# Altium schematic sheet traintrackr_schematic.SchDoc
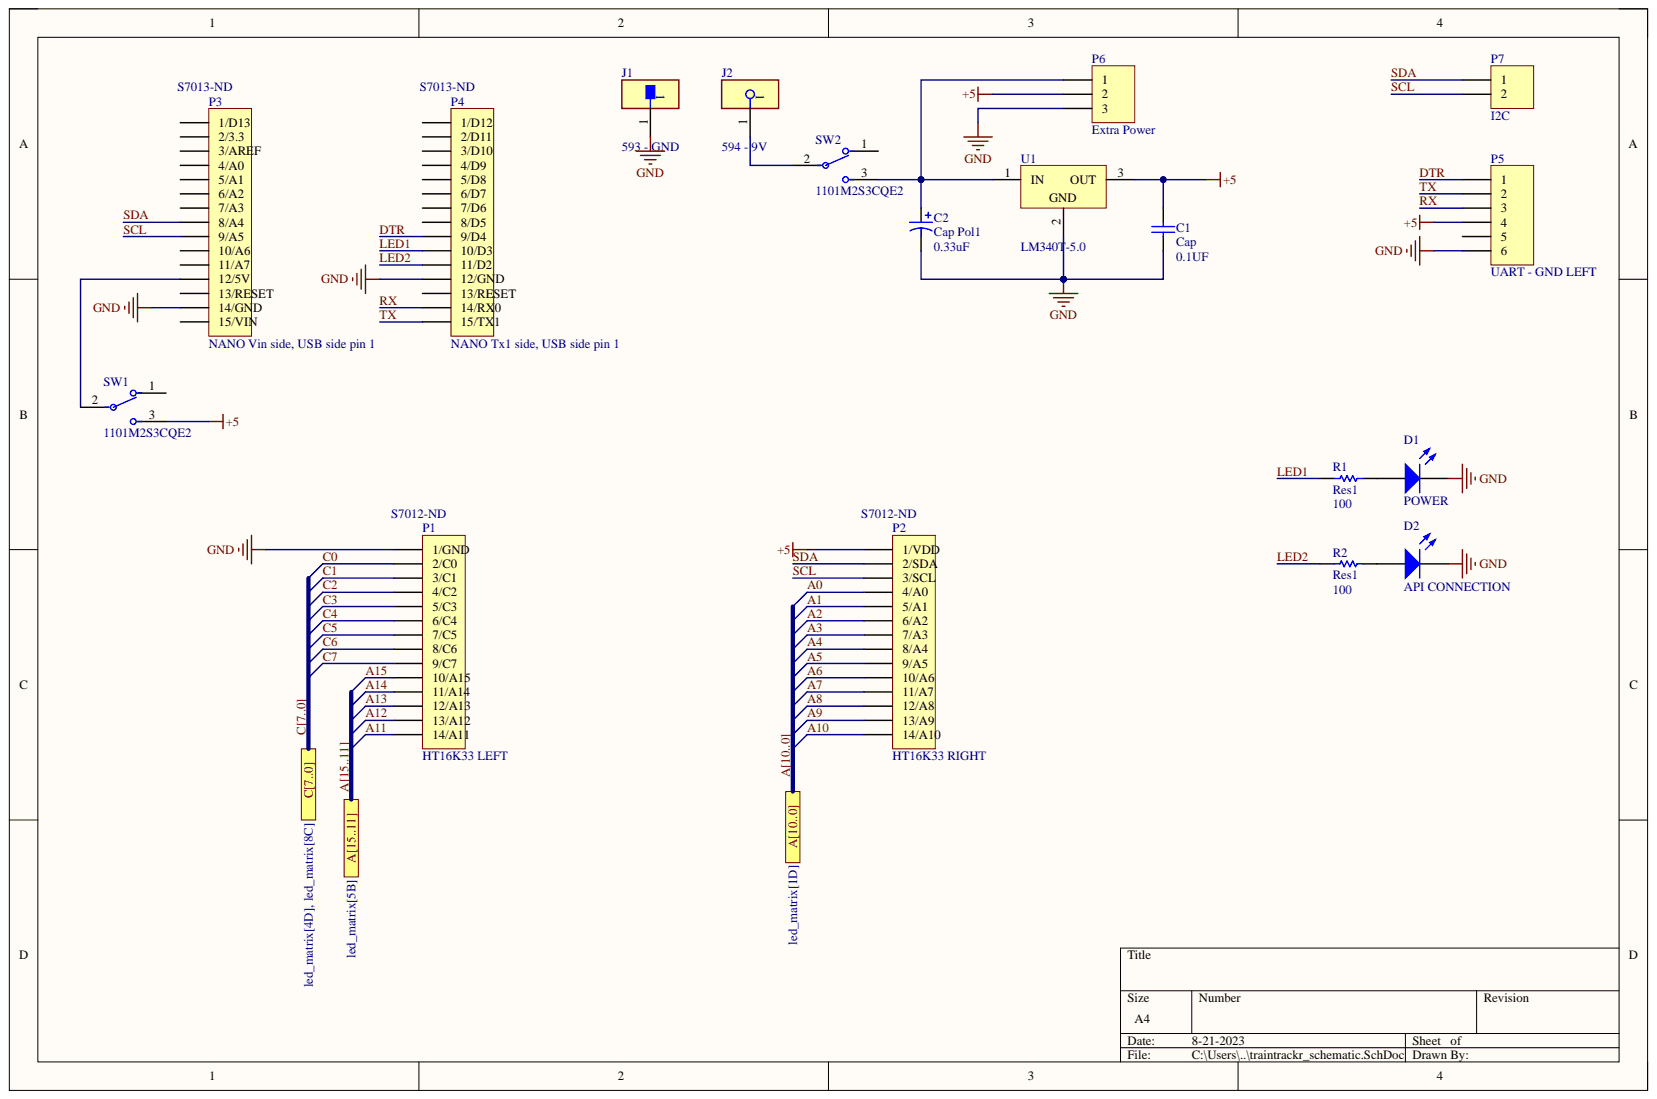
[diagram p0: traintrackr_schematic.SchDoc]

## Parts
C1  Cap  value 0.1UF  [RAD-0.1]
C2  Cap Pol1  value 0.33uF  [Capacitor 330nF]
D1  POWER  [LED_5MM]
D2  API CONNECTION  [LED_5MM]
J1  593 - GND  [FP-593-MFG]
J2  594 - 9V  [FP-594-MFG]
P1  HT16K33 LEFT  Supplier Part Number 1: S7012-ND  [HDR1X14]
P2  HT16K33 RIGHT  Supplier Part Number 1: S7012-ND  [HDR1X14]
P3  NANO Vin side, USB side pin 1  Supplier Part Number 1: S7013-ND  [HDR1X15]
P4  NANO Tx1 side, USB side pin 1  Supplier Part Number 1: S7013-ND  [HDR1X15]
P5  UART - GND LEFT  [HDR1X6H]
P6  Extra Power  [HDR1X3]
P7  I2C  [RAD-0.1]
R1  Res1  value 100  [AXIAL-0.3]
R2  Res1  value 100  [AXIAL-0.3]
SW1  1101M2S3CQE2  [FP-1101M2S3CQE2-MFG]
SW2  1101M2S3CQE2  [FP-1101M2S3CQE2-MFG]
U1  LM340T-5.0  [NDE0003A]

## Ports
A0, A1, A2, A3, A4, A5, A6, A7, A8, A9, A10, A11, A12, A13, A14, A15, A[10..0], A[15..11], C0, C1, C2, C3, C4, C5, C6, C7, C[7..0]

## Nets
+5: C1.2 P2.1 P5.4 P6.2 SW1.3 U1.3
GND: C1.1 C2.2 D1.2 D2.2 J1.1 P1.1 P3.14 P4.12 P5.6 P6.3 U1.2
A0: P2.4(4/A0) ; port A[10..0]  [also labelled A]
A1: P2.5(5/A1) ; port A[10..0]  [also labelled A]
A2: P2.6(6/A2) ; port A[10..0]  [also labelled A]
A3: P2.7(7/A3) ; port A[10..0]  [also labelled A]
A4: P2.8(8/A4) ; port A[10..0]  [also labelled A]
A5: P2.9(9/A5) ; port A[10..0]  [also labelled A]
A6: P2.10(10/A6) ; port A[10..0]  [also labelled A]
A7: P2.11(11/A7) ; port A[10..0]  [also labelled A]
A8: P2.12(12/A8) ; port A[10..0]  [also labelled A]
A9: P2.13(13/A9) ; port A[10..0]  [also labelled A]
A10: P2.14 ; port A[10..0]  [also labelled A]
A11: P1.14 ; port A[15..11]  [also labelled A]
A12: P1.13 ; port A[15..11]  [also labelled A]
A13: P1.12 ; port A[15..11]  [also labelled A]
A14: P1.11 ; port A[15..11]  [also labelled A]
A15: P1.10 ; port A[15..11]  [also labelled A]
C0: P1.2(2/C0) ; port C[7..0]  [also labelled C]
C1: P1.3(3/C1) ; port C[7..0]  [also labelled C]
C2: P1.4(4/C2) ; port C[7..0]  [also labelled C]
C3: P1.5(5/C3) ; port C[7..0]  [also labelled C]
C4: P1.6(6/C4) ; port C[7..0]  [also labelled C]
C5: P1.7(7/C5) ; port C[7..0]  [also labelled C]
C6: P1.8(8/C6) ; port C[7..0]  [also labelled C]
C7: P1.9(9/C7) ; port C[7..0]  [also labelled C]
DTR: P4.9(9/D4) P5.1
LED1: P4.10(10/D3) R1.1
LED2: P4.11(11/D2) R2.1
RX: P4.14 P5.3
SCL: P2.3(3/SCL) P3.9(9/A5) P7.2
SDA: P2.2 P3.8(8/A4) P7.1
TX: P4.15 P5.2
NetC2_1: C2.1 P6.1 SW2.3 U1.1
NetD1_1: D1.1 R1.2
NetD2_1: D2.1 R2.2
NetJ2_1: J2.1 SW2.2
NetP3_12: P3.12(12/5V) SW1.2
A[10..0]: ; port A[10..0]  [also labelled A]
A[15..11]: ; port A[15..11]  [also labelled A]

Not connected: P3 1(1/D13) 2(2/3.3) 3 4(4/A0) 5(5/A1) 6(6/A2) 7(7/A3) 10(10/A6) 11(11/A7) 13 15; P4 1(1/D12) 2(2/D11) 3(3/D10) 4(4/D9) 5(5/D8) 6(6/D7) 7(7/D6) 8(8/D5) 13; P5 5; SW1 1; SW2 1

## Library data of the parts
C1 = CAP CER 0.1UF 50V X7R RADIAL: Capacitor; Manufacturer Part Number: K104M15X7RF5TH5; Voltage Rating: 50V; Component Kind: Standard; Designator: C?; LatestRevisionDate: 17-Jul-2002; LatestRevisionNote: Re-released for DXP Platform.; Library Name: ECE295-Capacitors-TH.IntLib; Published: 8-Jun-2000; Signal Integrity: Cap; Simulation: CAP; Publisher: Altium Limited
C2 = Cap Pol1: Polarized Capacitor (Radial); Component Kind: Standard; LatestRevisionDate: 17-Jul-2002; LatestRevisionNote: Re-released for DXP Platform.; Library Name: Miscellaneous Devices.IntLib; PackageDescription: Polarized Capacitor (Radial); 2 Leads; PackageReference: RB7.6-15; Published: 8-Jun-2000; Signal Integrity: Cap Pol; Simulation: CAP; Publisher: Altium Limited
D1 D2 = LED1: Typical RED GaAs LED; Component Kind: Standard; LatestRevisionDate: 08-Jul-2005; LatestRevisionNote: Mech Layer 1 removed.; Library Name: Miscellaneous Devices.IntLib; PackageDescription: LED; 2 Leads; PackageReference: LED-1; Published: 8-Jun-2000; Simulation: LED1; Publisher: Altium Limited
J1 = CMP-19636-000031-1: BATTERY CONTACT SNAP 9V PC PIN; Body Material: Steel; Body Plating: Tin; Connector Type: Snap in; Gender: Male; Material Thickness: 0.15inch; Number of Batteries: 1; Component Kind: Standard; Contact Material: Steel; Contact Plating: Nickel; Contact Type: Snap-In; Lead Free: Lead Free; Length: 9.65mm; Library Name: Altium Content Vault; Material: Steel; Mount: Through Hole; Number of Cells: 1; Orientation: Right Angle; Package Quantity: 100; Packaging: Bulk; Reach SVHC Compliant: Yes; RoHS Compliant: Yes; Series: -; Termination: PC Pin; Thickness: 0.015inch
J2 = CMP-19636-000029-1: BATTERY CONTACT SNAP 9V PC PIN; Body Material: Steel; Body Plating: Tin; Connector Type: Snap in; Gender: Female; Material Thickness: 0.15inch; Number of Batteries: 1; Component Kind: Standard; Contact Material: Steel; Contact Plating: Nickel; Contact Type: Snap-In; Lead Free: Lead Free; Length: 9.65mm; Library Name: Altium Content Vault; Material: Steel; Mount: Through Hole; Number of Cells: 1; Orientation: Right Angle; Package Quantity: 100; Packaging: Bulk; Reach SVHC Compliant: Yes; RoHS Compliant: Yes; Series: -; Termination: PC Pin; Thickness: 0.015inch
P1 P2 = Header 14: Header, 14-Pin; Component Kind: Standard; LatestRevisionDate: 17-Jul-2002; LatestRevisionNote: Re-released for DXP Platform.; Library Name: Miscellaneous Connectors.IntLib; Signal Integrity: Connector; Supplier 1: Digi-Key; Publisher: Altium Limited
P3 P4 = Header 15: Header, 15-Pin; Component Kind: Standard; LatestRevisionDate: 17-Jul-2002; LatestRevisionNote: Re-released for DXP Platform.; Library Name: Miscellaneous Connectors.IntLib; Signal Integrity: Connector; Supplier 1: Digi-Key; Publisher: Altium Limited
P5 = Header 6H: Header, 6-Pin, Right Angle; Component Kind: Standard; LatestRevisionDate: 17-Jul-2002; LatestRevisionNote: Re-released for DXP Platform.; Library Name: Miscellaneous Connectors.IntLib; Signal Integrity: Connector; Supplier 1: Digi-Key; Supplier Part Number 1: S1111EC-06-ND; Publisher: Altium Limited
P6 = Header 3: Header, 3-Pin; Component Kind: Standard; LatestRevisionDate: 17-Jul-2002; LatestRevisionNote: Re-released for DXP Platform.; Library Name: Miscellaneous Connectors.IntLib; Signal Integrity: Connector; Supplier 1: Digi-Key; Supplier Part Number 1: 2057-PH1-20-UA-ND; Publisher: Altium Limited
P7 = Header 2H: Header, 2-Pin, Right Angle; Component Kind: Standard; LatestRevisionDate: 17-Jul-2002; LatestRevisionNote: Re-released for DXP Platform.; Library Name: Miscellaneous Connectors.IntLib; Signal Integrity: Connector; Supplier 1: Digi-Key; Supplier Part Number 1: 2057-PH1-20-UA-ND; Publisher: Altium Limited
R1 R2 = Res1: Resistor; Component Kind: Standard; LatestRevisionDate: 17-Jul-2002; LatestRevisionNote: Re-released for DXP Platform.; Library Name: Miscellaneous Devices.IntLib; PackageDescription: Axial Device, Thru-Hole; 2 Leads; 0.3 in Pin Spacing; PackageReference: AXIAL-0.3; Published: 8-Jun-2000; Signal Integrity: Res; Simulation: RESISTOR; Publisher: Altium Limited
SW1 SW2 = CMP-10038-000019-1: SWITCH SLIDE SPDT 6A 120V; Actuator Color: Black; Actuator Length: 5.08mm; Actuator Material: Nylon; Actuator Type: Standard; Approvals: UL; Body Material: Stainless Steel; Contact Resistance: 10mR; Electrical Life: 40000Cycles; Insulation Resistance: 1GR; Max Voltage Rating (AC): 250V; Max Voltage Rating (DC): 28V; Number of Poles: 1; Number of Positions: 3; Switch Function: On-On; Switch Travel: 2.16mm; Component Kind: Standard; Contact Current Rating: 6A; Contact Material: Copper; Contact Plating: Silver; Current Rating: 6A; Depth: 6.6mm; Flammability Rating: UL94 V-0; Housing Material: Stainless Steel; Lead Free: Lead Free; Length: 12.7mm; Library Name: Altium Content Vault; Max Current Rating: 6A; Max Operating Temperature: 65°C; Min Operating Temperature: -30°C; Mount: Through Hole; Orientation: Vertical; Package Quantity: 1; Packaging: Bulk; REACH SVHC: No SVHC; Series: 1000; Termination: PC Pins; Throw Configuration: SPDT; Voltage Rating (AC): 120V; Voltage Rating (DC): 28V
U1 = CMP-0062-02468-3: Series 3-Terminal Positive Regulators, 3-pin TO-220; Manufacturer: Texas Instruments; CompType: LDO IC; PackageTopMarking: NSUZXYTTE#*LM340T5*7805 P+; PowerWise: N; Component Kind: Standard; ComponentLink1Description: Datasheet URL; ComponentLink1URL: http://www.ti.com/general/docs/lit/getliterature.tsp?genericPartNumber=LM340-N&fileType=pdf; Library Name: Altium Content Vault; PackageDescription: TO-220, Molded, 3 Lead, Straight; PackageReference: NDE0003A; PinCount: 3; RoHS: N
